# Altium schematic sheet ASB.SchDoc
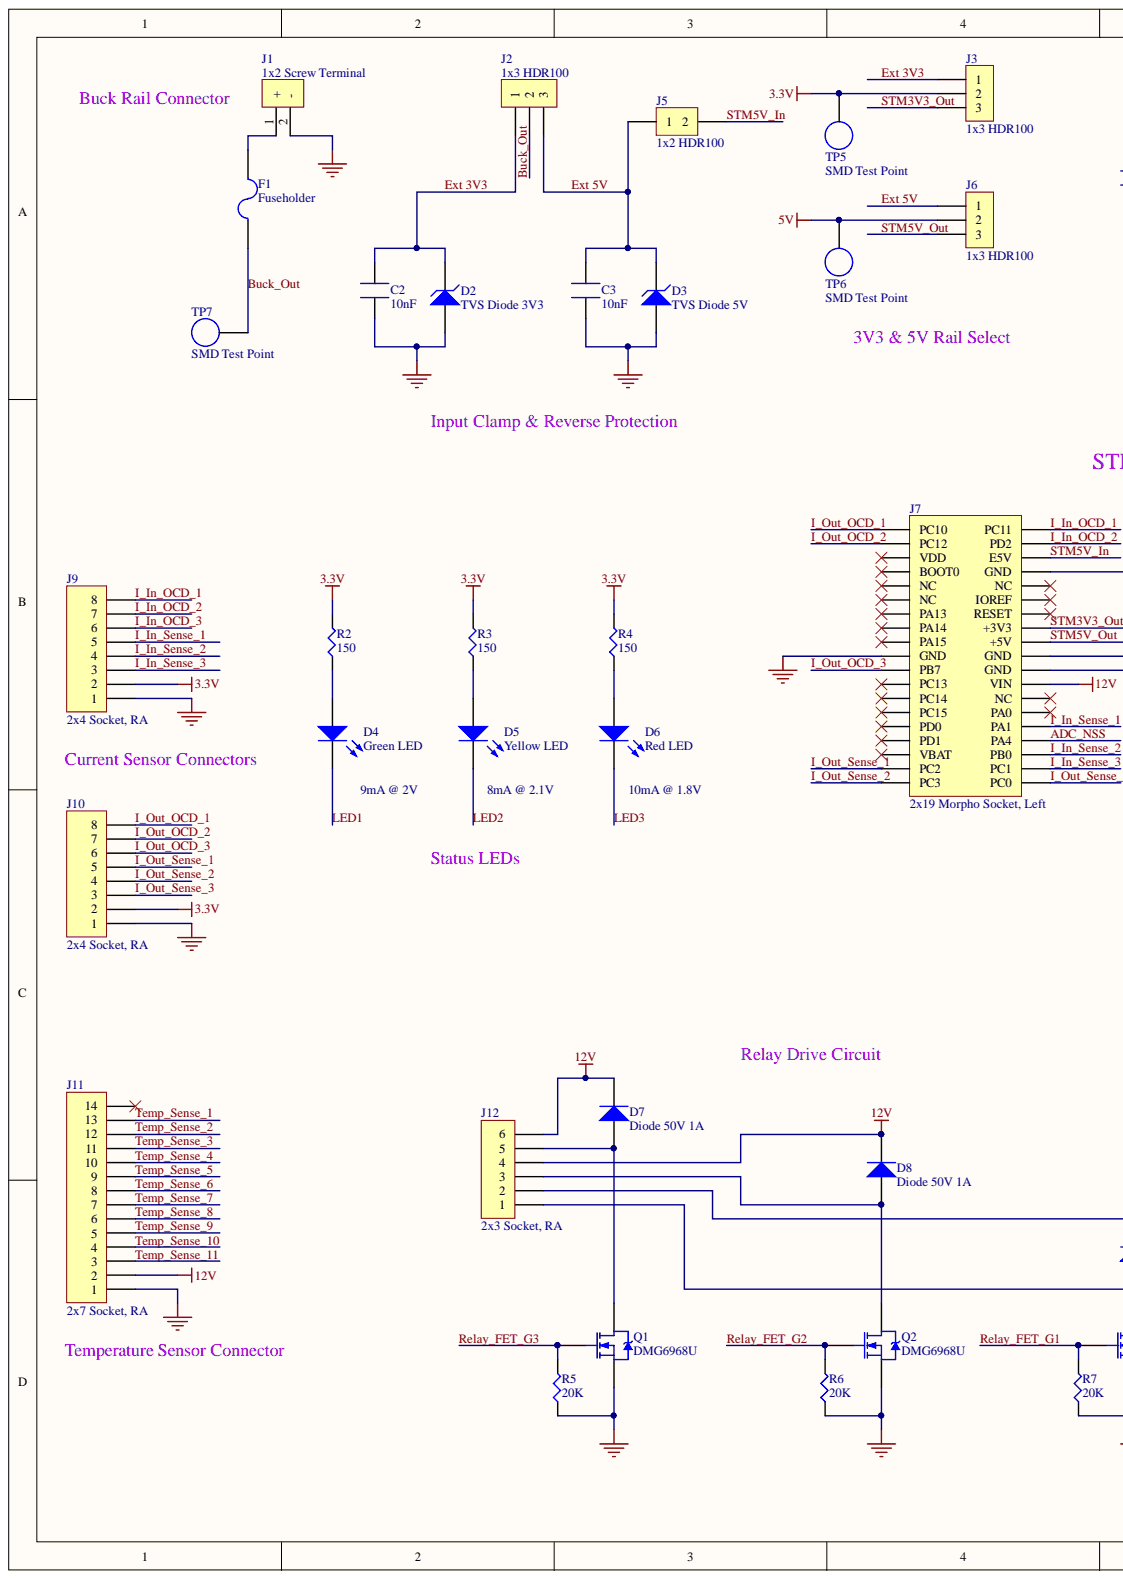
[diagram p0: ASB.SchDoc, left]
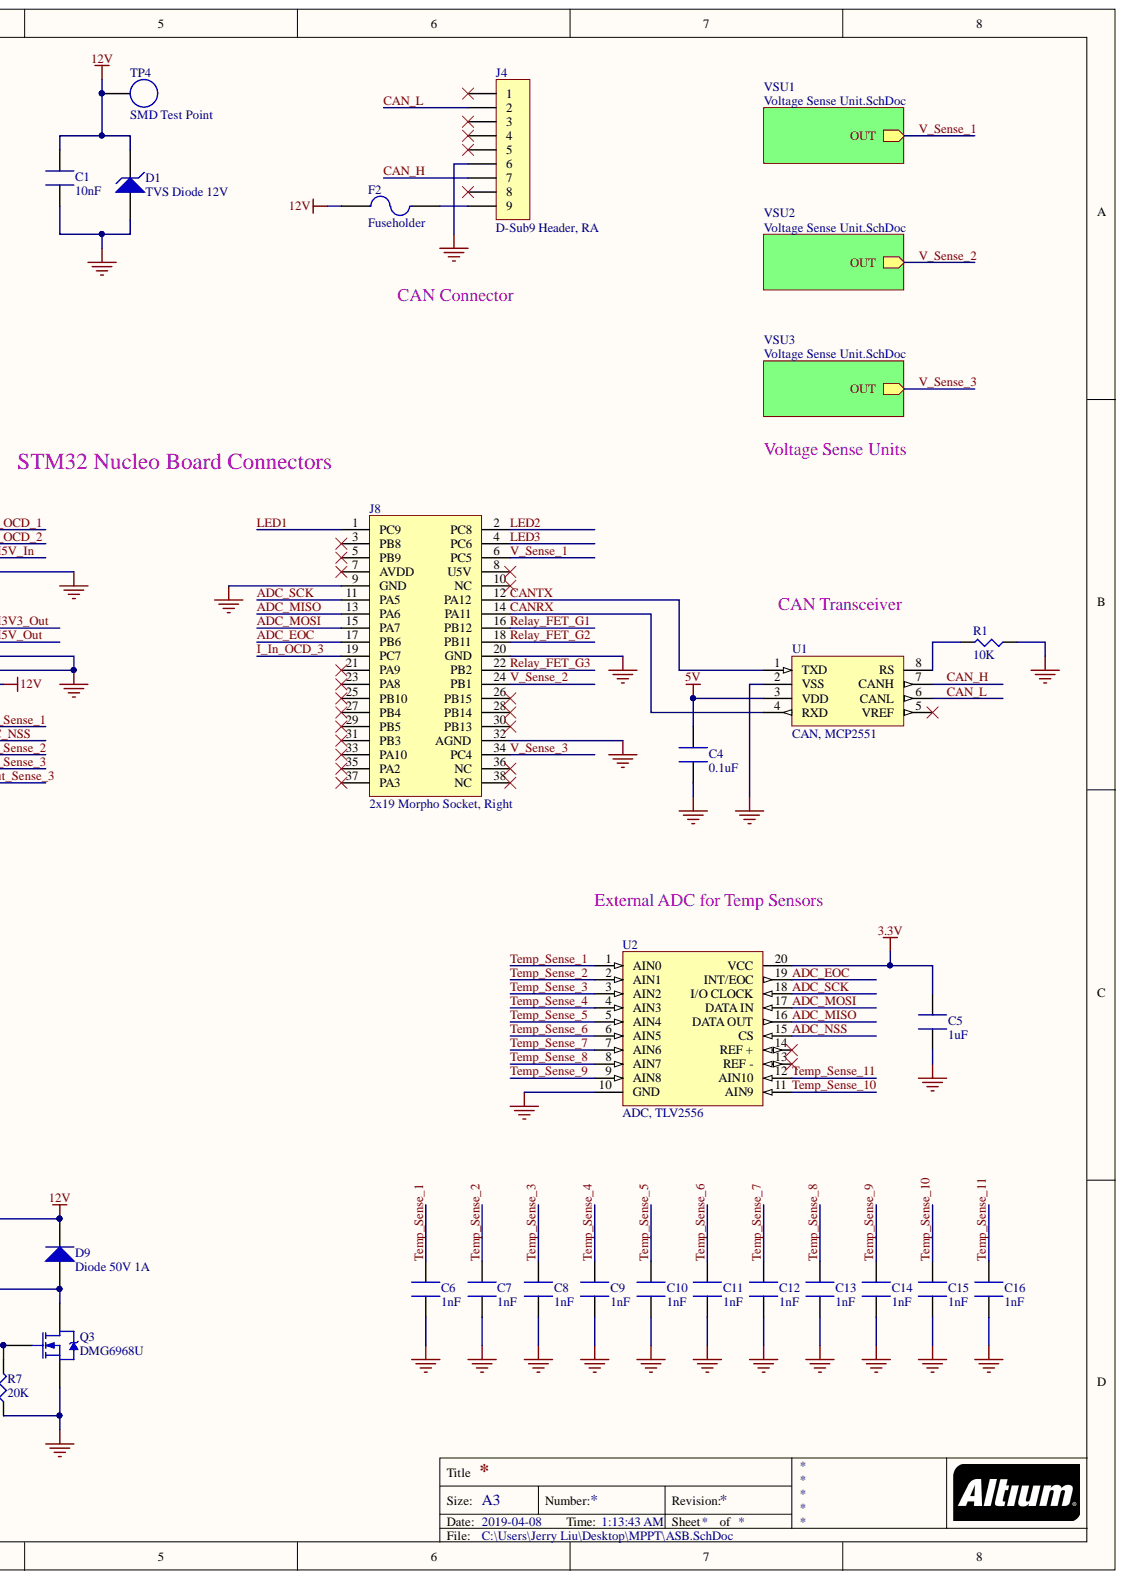
[diagram p1: ASB.SchDoc, right]

## Parts
C1  10nF
C2  10nF
C3  10nF
C4  0.1uF
C5  1uF
C6  1nF
C7  1nF
C8  1nF
C9  1nF
C10  1nF
C11  1nF
C12  1nF
C13  1nF
C14  1nF
C15  1nF
C16  1nF
D1  TVS Diode 12V
D2  TVS Diode 3V3
D3  TVS Diode 5V
D4  Green LED  Color: Green
D5  Yellow LED  Color: Yellow  Forward Voltage: 2.1V
D6  Red LED  Color: Red
D7  Diode 50V 1A
D8  Diode 50V 1A
D9  Diode 50V 1A
F1  Fuseholder
F2  Fuseholder
J1  1x2 Screw Terminal
J2  1x3 HDR100
J3  1x3 HDR100
J4  D-Sub9 Header, RA
J5  1x2 HDR100
J6  1x3 HDR100
J7  2x19 Morpho Socket, Left
J8  2x19 Morpho Socket, Right
J9  2x4 Socket, RA
J10  2x4 Socket, RA
J11  2x7 Socket, RA
J12  2x3 Socket, RA
Q1  DMG6968U
Q2  DMG6968U
Q3  DMG6968U
R1  10K
R2  150
R3  150
R4  150
R5  20K
R6  20K
R7  20K
TP4  SMD Test Point
TP5  SMD Test Point
TP6  SMD Test Point
TP7  SMD Test Point
U1  CAN, MCP2551
U2  ADC, TLV2556

## Nets
3.3V: C5.2 J3.2 J9.2 J10.2 R2.2 R3.2 R4.2 TP5.1 U2.20(VCC)
5V: C4.2 J6.2 TP6.1 U1.3(VDD)
12V: C1.2 D1.2 D7.2 D8.2 D9.2 F2.1 J7.24(VIN) J11.2 J12.2 J12.4 J12.6 TP4.1
GND: C1.1 C2.1 C3.1 C4.1 C5.1 C6.1 C7.1 C8.1 C9.1 C10.1 C11.1 C12.1 C13.1 C14.1 C15.1 C16.1 D1.1 D2.1 D3.1 J1.2 J4.6 J7.8(GND) J7.19(GND) J7.20(GND) J7.22(GND) J8.9(GND) J8.20(GND) J8.32(AGND) J9.1 J10.1 J11.1 Q1.3 Q2.3 Q3.3 R1.1 R5.1 R6.1 R7.1 U1.2(VSS) U2.10(GND)
ADC_EOC: J8.17(PB6) U2.19(INT/EOC)
ADC_MISO: J8.13(PA6) U2.16(DATA_OUT)
ADC_MOSI: J8.15(PA7) U2.17(DATA_IN)
ADC_NSS: J7.32(PA4) U2.15(CS)
ADC_SCK: J8.11(PA5) U2.18(I/O_CLOCK)
Buck_Out: F1.2 J2.2 TP7.1
CAN_H: J4.7 U1.7(CANH)
CAN_L: J4.2 U1.6(CANL)
CANRX: J8.14(PA11) U1.4(RXD)
CANTX: J8.12(PA12) U1.1(TXD)
Ext 3V3: C2.2 D2.2 J2.1 J3.1
Ext 5V: C3.2 D3.2 J2.3 J5.1 J6.1
I_In_OCD_1: J7.2(PC11) J9.8
I_In_OCD_2: J7.4(PD2) J9.7
I_In_OCD_3: J8.19(PC7) J9.6
I_In_Sense_1: J7.30(PA1) J9.5
I_In_Sense_2: J7.34(PB0) J9.4
I_In_Sense_3: J7.36(PC1) J9.3
I_Out_OCD_1: J7.1(PC10) J10.8
I_Out_OCD_2: J7.3(PC12) J10.7
I_Out_OCD_3: J7.21(PB7) J10.6
I_Out_Sense_1: J7.35(PC2) J10.5
I_Out_Sense_2: J7.37(PC3) J10.4
I_Out_Sense_3: J7.38(PC0) J10.3
LED1: D4.2 J8.1(PC9)
LED2: D5.2 J8.2(PC8)
LED3: D6.2 J8.4(PC6)
Relay_FET_G1: J8.16(PB12) Q3.1 R7.2
Relay_FET_G2: J8.18(PB11) Q2.1 R6.2
Relay_FET_G3: J8.22(PB2) Q1.1 R5.2
STM3V3_Out: J3.3 J7.16(+3V3)
STM5V_In: J5.2 J7.6(E5V)
STM5V_Out: J6.3 J7.18(+5V)
Temp_Sense_1: C6.2 J11.13 U2.1(AIN0)
Temp_Sense_2: C7.2 J11.12 U2.2(AIN1)
Temp_Sense_3: C8.2 J11.11 U2.3(AIN2)
Temp_Sense_4: C9.2 J11.10 U2.4(AIN3)
Temp_Sense_5: C10.2 J11.9 U2.5(AIN4)
Temp_Sense_6: C11.2 J11.8 U2.6(AIN5)
Temp_Sense_7: C12.2 J11.7 U2.7(AIN6)
Temp_Sense_8: C13.2 J11.6 U2.8(AIN7)
Temp_Sense_9: C14.2 J11.5 U2.9(AIN8)
Temp_Sense_10: C15.2 J11.4 U2.11(AIN9)
Temp_Sense_11: C16.2 J11.3 U2.12(AIN10)
V_Sense_1: J8.6(PC5)
V_Sense_2: J8.24(PB1)
V_Sense_3: J8.34(PC4)
NetD4_1: D4.1 R2.1
NetD5_1: D5.1 R3.1
NetD6_1: D6.1 R4.1
NetD7_1: D7.1 J12.5 Q1.2
NetD8_1: D8.1 J12.3 Q2.2
NetD9_1: D9.1 J12.1 Q3.2
NetF1_1: F1.1 J1.1
NetF2_2: F2.2 J4.9
NetJ4_1: J4.1
NetJ4_3: J4.3
NetJ4_4: J4.4
NetJ4_5: J4.5
NetJ4_8: J4.8
NetJ7_5: J7.5(VDD)
NetJ7_7: J7.7(BOOT0)
NetJ7_9: J7.9(NC)
NetJ7_10: J7.10(NC)
NetJ7_11: J7.11(NC)
NetJ7_12: J7.12(IOREF)
NetJ7_13: J7.13(PA13)
NetJ7_14: J7.14(RESET)
NetJ7_15: J7.15(PA14)
NetJ7_17: J7.17(PA15)
NetJ7_23: J7.23(PC13)
NetJ7_25: J7.25(PC14)
NetJ7_26: J7.26(NC)
NetJ7_27: J7.27(PC15)
NetJ7_28: J7.28(PA0)
NetJ7_29: J7.29(PD0)
NetJ7_31: J7.31(PD1)
NetJ7_33: J7.33(VBAT)
NetJ8_3: J8.3(PB8)
NetJ8_5: J8.5(PB9)
NetJ8_7: J8.7(AVDD)
NetJ8_8: J8.8(U5V)
NetJ8_10: J8.10(NC)
NetJ8_21: J8.21(PA9)
NetJ8_23: J8.23(PA8)
NetJ8_25: J8.25(PB10)
NetJ8_26: J8.26(PB15)
NetJ8_27: J8.27(PB4)
NetJ8_28: J8.28(PB14)
NetJ8_29: J8.29(PB5)
NetJ8_30: J8.30(PB13)
NetJ8_31: J8.31(PB3)
NetJ8_33: J8.33(PA10)
NetJ8_35: J8.35(PA2)
NetJ8_36: J8.36(NC)
NetJ8_37: J8.37(PA3)
NetJ8_38: J8.38(NC)
NetJ11_14: J11.14
NetR1_2: R1.2 U1.8(RS)
NetU1_5: U1.5(VREF)
NetU2_13: U2.13(REF_-)
NetU2_14: U2.14(REF_+)
